# Altium schematic sheet CAR_FAN_RELAY_2.SchDoc(CAR_FAN_RELAY_2)
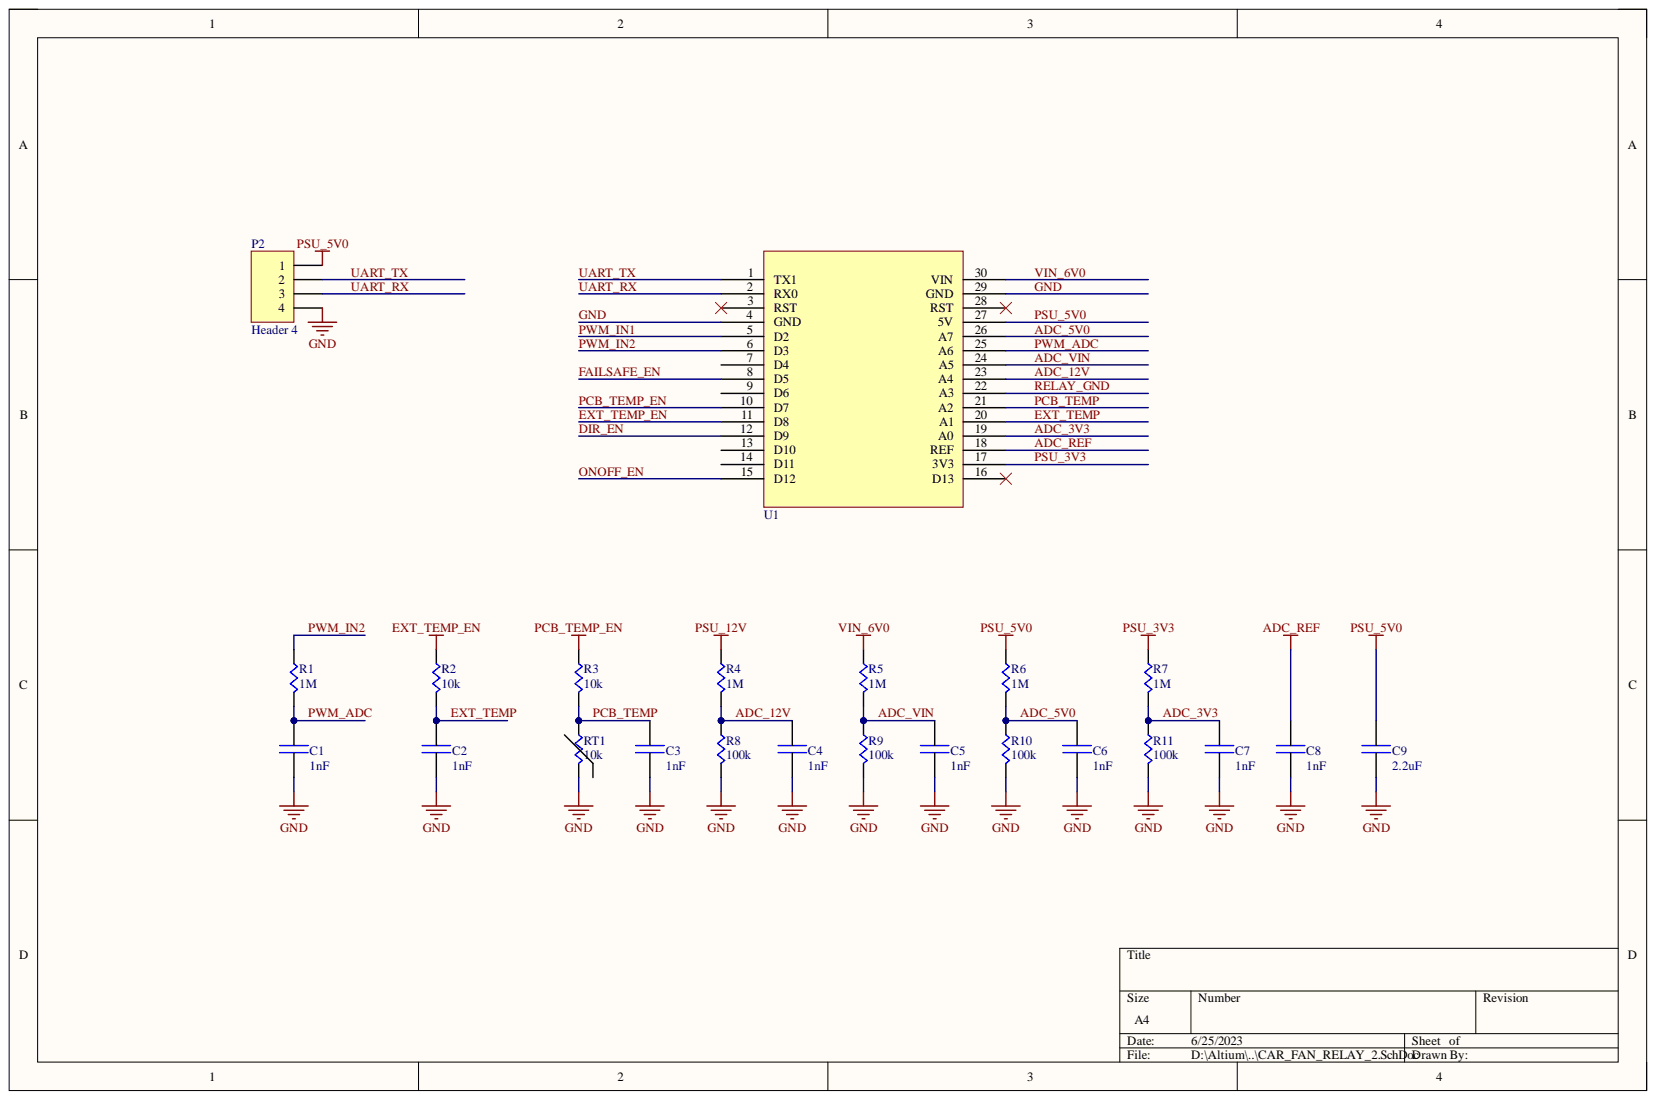
[diagram p0: CAR_FAN_RELAY_2.SchDoc]

## Parts
C1  1nF  [0603]
C2  1nF  [0603]
C3  1nF  [0603]
C4  1nF  [0603]
C5  1nF  [0603]
C6  1nF  [0603]
C7  1nF  [0603]
C8  1nF  [0603]
C9  2.2uF  [0805]
P2  Header 4  [HDR1X4]
R1  1M  [0603]
R2  10k  [0603]
R3  10k  [0603]
R4  1M  [0603]
R5  1M  [0603]
R6  1M  [0603]
R7  1M  [0603]
R8  100k  [0603]
R9  100k  [0603]
R10  100k  [0603]
R11  100k  [0603]
RT1  10k  [0603]
U1  ARDUINO NANO  [ARDUINO_NANO]  (value not drawn)

## Nets
PSU_12V: R4.1
ADC_3V3: C7.1 R7.2 R11.1 U1.19(A0)
ADC_5V0: C6.1 R6.2 R10.1 U1.26(A7)
ADC_12V: C4.1 R4.2 R8.1 U1.23(A4)
ADC_REF: C8.1 U1.18(REF)
ADC_VIN: C5.1 R5.2 R9.1 U1.24(A5)
DIR_EN: U1.12(D9)
EXT_TEMP: C2.1 R2.2 U1.20(A1)
EXT_TEMP_EN: R2.1 U1.11(D8)
FAILSAFE_EN: U1.8(D5)
GND: C1.2 C2.2 C3.2 C4.2 C5.2 C6.2 C7.2 C8.2 C9.2 P2.4 R8.2 R9.2 R10.2 R11.2 RT1.2 U1.4(GND) U1.29(GND)
ONOFF_EN: U1.15(D12)
PCB_TEMP: C3.1 R3.2 RT1.1 U1.21(A2)
PCB_TEMP_EN: R3.1 U1.10(D7)
PSU_3V3: R7.1 U1.17(3V3)
PSU_5V0: C9.1 P2.1 R6.1 U1.27(5V)
PWM_ADC: C1.1 R1.2 U1.25(A6)
PWM_IN1: U1.5(D2)
PWM_IN2: R1.1 U1.6(D3)
RELAY_GND: U1.22(A3)
UART_RX: P2.3 U1.2(RX0)
UART_TX: P2.2 U1.1(TX1)
VIN_6V0: R5.1 U1.30(VIN)

Not connected: U1 3(RST) 7(D4) 9(D6) 13(D10) 14(D11) 16(D13) 28(RST)

## Library data of the parts
C1-C9 = CAP: Unpolarised capacitor; Component Kind: Standard
  C9: Library Name: CAR_FAN_RELAY.SchLib
  C1-C8: Library Name: BackscatterArraySizeSwitch.SchLib
P2 = Header 4: Header, 4-Pin; Component Kind: Standard; LatestRevisionDate: 17-Jul-2002; LatestRevisionNote: Re-released for DXP Platform.; Library Name: Miscellaneous Connectors.IntLib; Signal Integrity: Connector; Publisher: Altium Limited
R1-R11 = RES: Resistor; Component Kind: Standard; Library Name: BackscatterArraySizeSwitch.SchLib
RT1 = RES: Thermistor; Component Kind: Standard; Library Name: BackscatterArraySizeSwitch.SchLib
U1 = ARDUINO_NANO: Component Kind: Standard; Library Name: BackscatterArraySizeSwitch.SchLib
